# Altium schematic sheet photoreflective_face.SchDoc
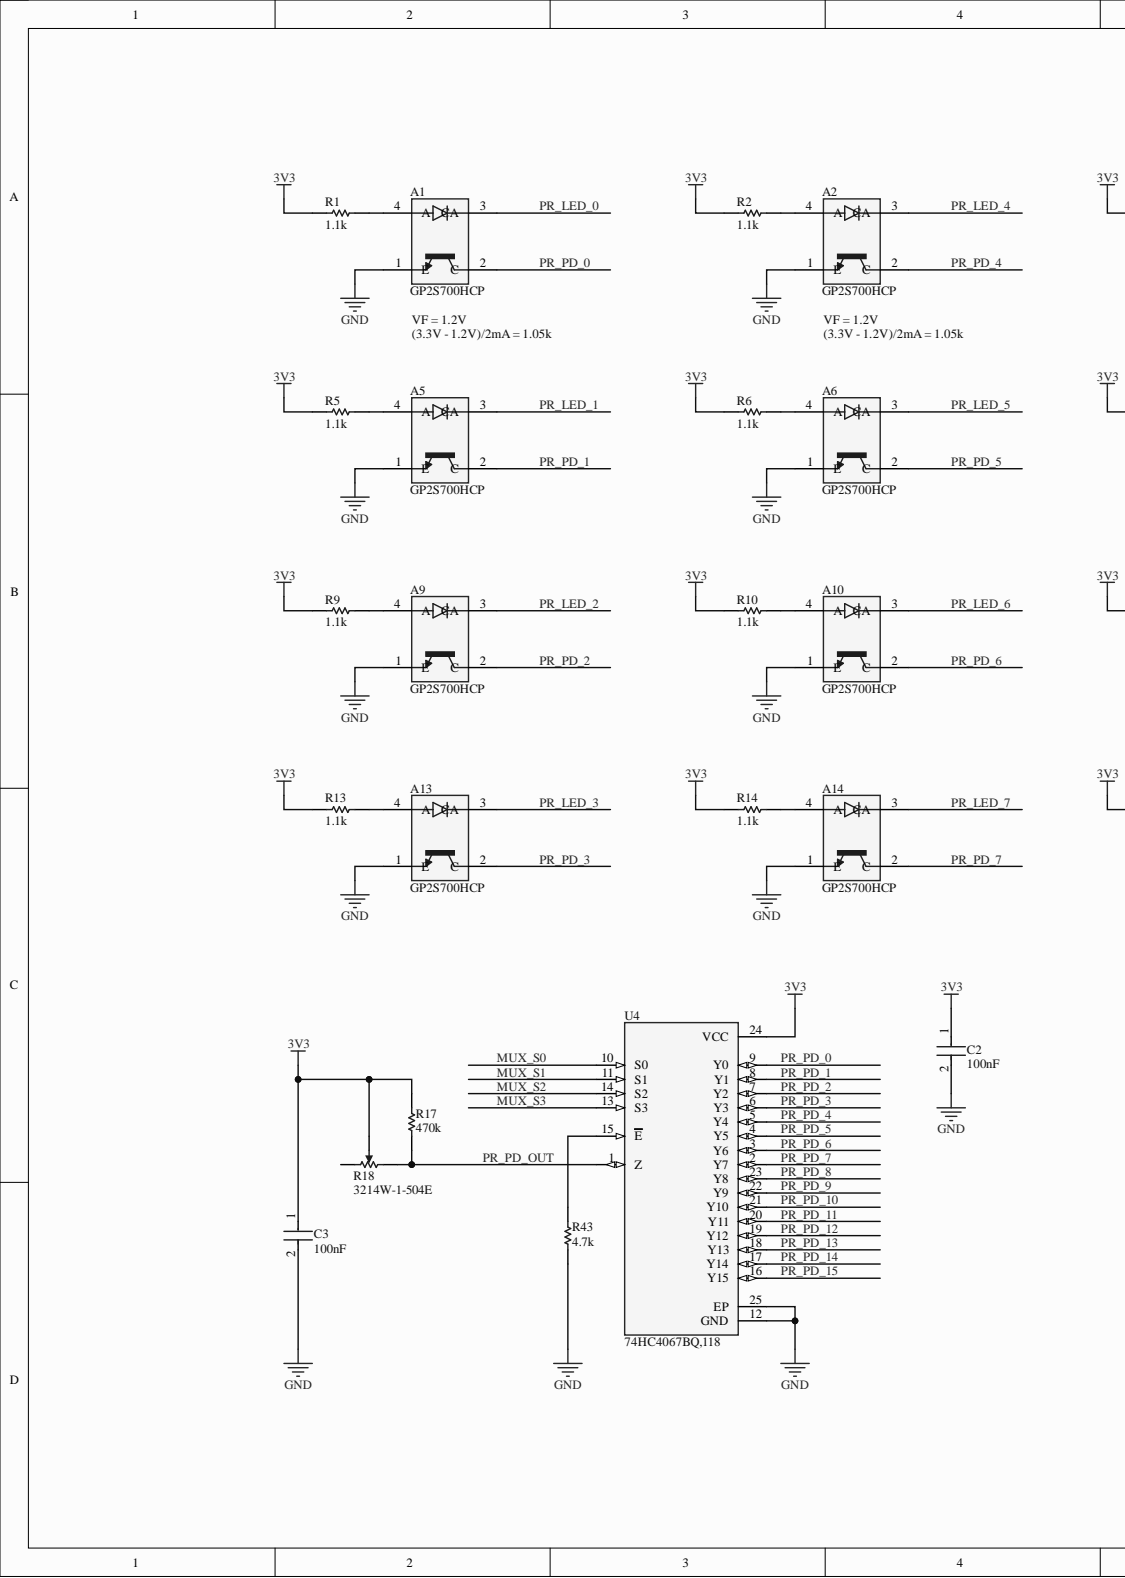
[diagram p0: photoreflective_face.SchDoc, left]
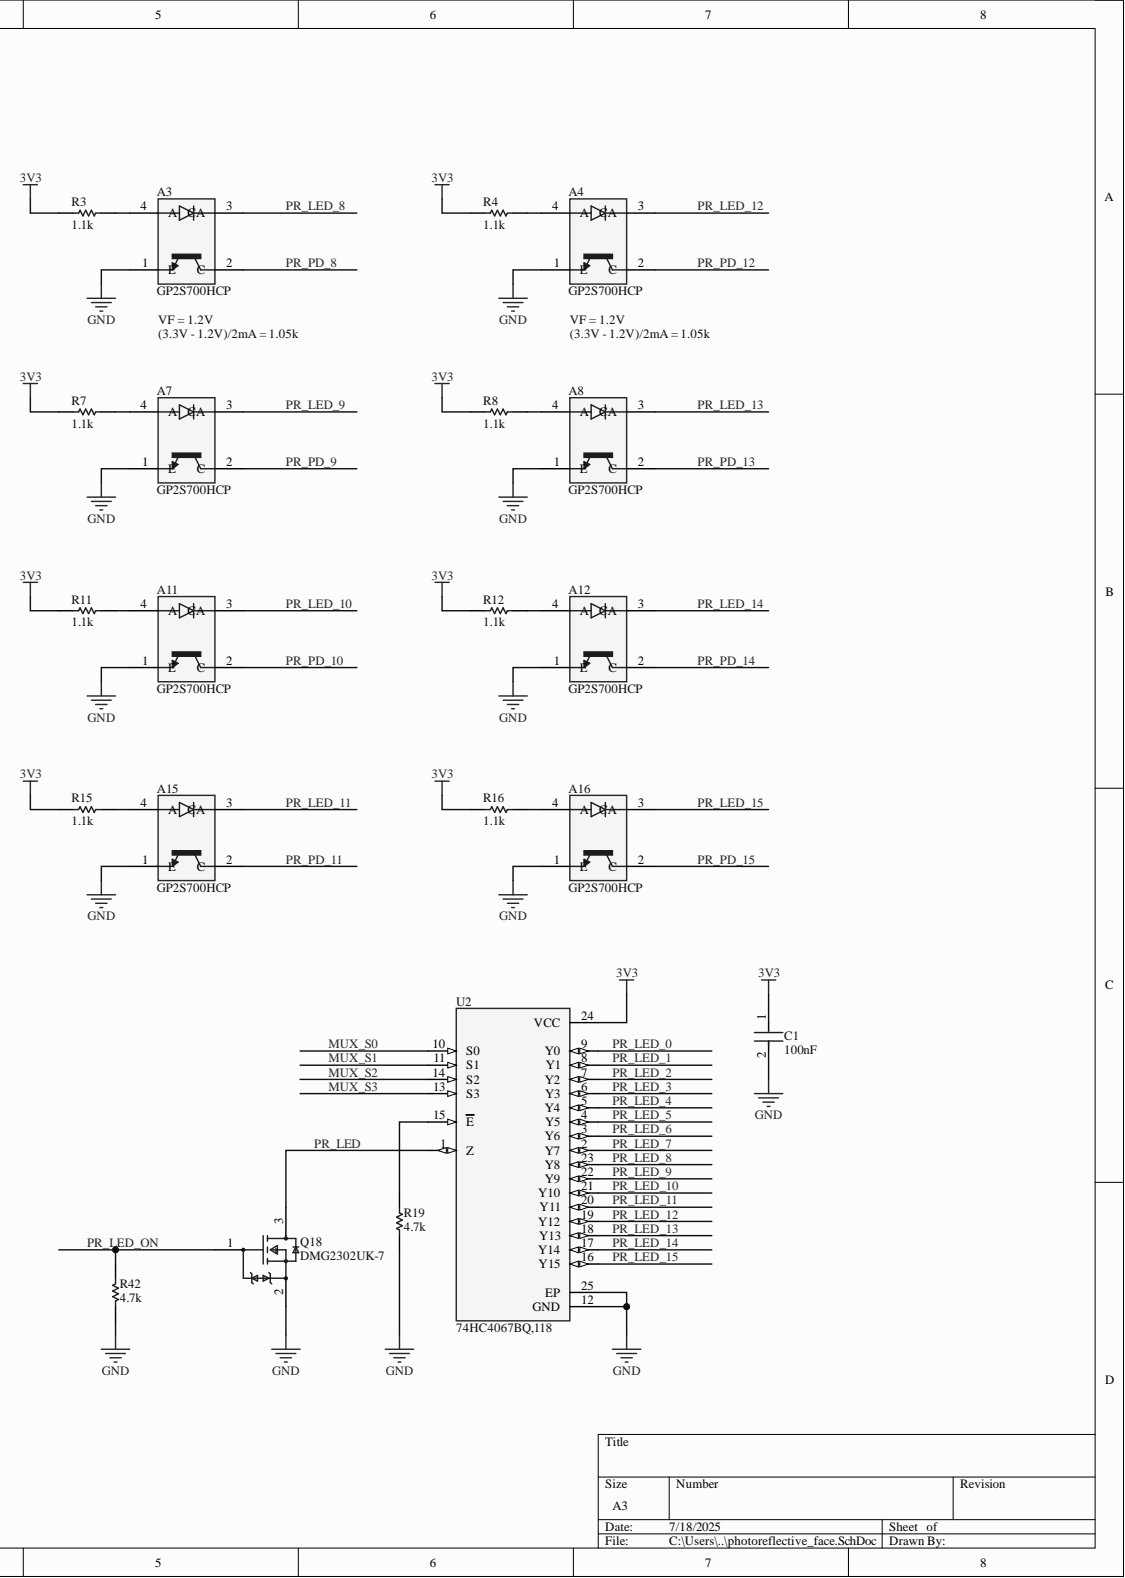
[diagram p1: photoreflective_face.SchDoc, right]

## Parts
A1  GP2S700HCP  [XDCR_GP2S700HCP]
A2  GP2S700HCP  [XDCR_GP2S700HCP]
A3  GP2S700HCP  [XDCR_GP2S700HCP]
A4  GP2S700HCP  [XDCR_GP2S700HCP]
A5  GP2S700HCP  [XDCR_GP2S700HCP]
A6  GP2S700HCP  [XDCR_GP2S700HCP]
A7  GP2S700HCP  [XDCR_GP2S700HCP]
A8  GP2S700HCP  [XDCR_GP2S700HCP]
A9  GP2S700HCP  [XDCR_GP2S700HCP]
A10  GP2S700HCP  [XDCR_GP2S700HCP]
A11  GP2S700HCP  [XDCR_GP2S700HCP]
A12  GP2S700HCP  [XDCR_GP2S700HCP]
A13  GP2S700HCP  [XDCR_GP2S700HCP]
A14  GP2S700HCP  [XDCR_GP2S700HCP]
A15  GP2S700HCP  [XDCR_GP2S700HCP]
A16  GP2S700HCP  [XDCR_GP2S700HCP]
C1  Capacitance: 100nF  [FP-GRM15-MFG]  (hidden comment: GRM155R71C104KA88D)
C2  Capacitance: 100nF  [FP-GRM15-MFG]  (hidden comment: GRM155R71C104KA88D)
C3  Capacitance: 100nF  [FP-GRM15-MFG]  (hidden comment: GRM155R71C104KA88D)
Q18  DMG2302UK-7  [FP-SOT23-MFG]
R1  value 1.1k  [RESC1005X40X25LL05T05]  (hidden comment: ERJ-2GEJ112X)
R2  value 1.1k  [RESC1005X40X25LL05T05]  (hidden comment: ERJ-2GEJ112X)
R3  value 1.1k  [RESC1005X40X25LL05T05]  (hidden comment: ERJ-2GEJ112X)
R4  value 1.1k  [RESC1005X40X25LL05T05]  (hidden comment: ERJ-2GEJ112X)
R5  value 1.1k  [RESC1005X40X25LL05T05]  (hidden comment: ERJ-2GEJ112X)
R6  value 1.1k  [RESC1005X40X25LL05T05]  (hidden comment: ERJ-2GEJ112X)
R7  value 1.1k  [RESC1005X40X25LL05T05]  (hidden comment: ERJ-2GEJ112X)
R8  value 1.1k  [RESC1005X40X25LL05T05]  (hidden comment: ERJ-2GEJ112X)
R9  value 1.1k  [RESC1005X40X25LL05T05]  (hidden comment: ERJ-2GEJ112X)
R10  value 1.1k  [RESC1005X40X25LL05T05]  (hidden comment: ERJ-2GEJ112X)
R11  value 1.1k  [RESC1005X40X25LL05T05]  (hidden comment: ERJ-2GEJ112X)
R12  value 1.1k  [RESC1005X40X25LL05T05]  (hidden comment: ERJ-2GEJ112X)
R13  value 1.1k  [RESC1005X40X25LL05T05]  (hidden comment: ERJ-2GEJ112X)
R14  value 1.1k  [RESC1005X40X25LL05T05]  (hidden comment: ERJ-2GEJ112X)
R15  value 1.1k  [RESC1005X40X25LL05T05]  (hidden comment: ERJ-2GEJ112X)
R16  value 1.1k  [RESC1005X40X25LL05T05]  (hidden comment: ERJ-2GEJ112X)
R17  value 470k  [RESC1005X40X25ML05T05]  (hidden comment: CRCW0402470KFKED)
R18  3214W-1-504E  [BOUR-3214W_V]
R19  value 4.7k  [RESC1005X40X25LL05T10]  (hidden comment: CRCW04024K70FKEDHP)
R42  value 4.7k  [RESC1005X40X25LL05T10]  (hidden comment: CRCW04024K70FKEDHP)
R43  value 4.7k  [RESC1005X40X25LL05T10]  (hidden comment: CRCW04024K70FKEDHP)
U2  74HC4067BQ,118  [QFN50P550X350X100-25N410X210]
U4  74HC4067BQ,118  [QFN50P550X350X100-25N410X210]

## Nets
3V3: C1.1 C2.1 C3.1 R1.2 R2.2 R3.2 R4.2 R5.2 R6.2 R7.2 R8.2 R9.2 R10.2 R11.2 R12.2 R13.2 R14.2 R15.2 R16.2 R17.1 R18.2 U2.24(VCC) U4.24(VCC)
GND: A1.1(E) A2.1(E) A3.1(E) A4.1(E) A5.1(E) A6.1(E) A7.1(E) A8.1(E) A9.1(E) A10.1(E) A11.1(E) A12.1(E) A13.1(E) A14.1(E) A15.1(E) A16.1(E) C1.2 C2.2 C3.2 Q18.2 R19.1 R42.1 R43.1 U2.12(GND) U2.25(EP) U4.12(GND) U4.25(EP)
MUX_S0: U2.10(S0) U4.10(S0)
MUX_S1: U2.11(S1) U4.11(S1)
MUX_S2: U2.14(S2) U4.14(S2)
MUX_S3: U2.13(S3) U4.13(S3)
PR_LED: Q18.3 U2.1(Z)
PR_LED_0: A1.3(CA) U2.9(Y0)
PR_LED_1: A5.3(CA) U2.8(Y1)
PR_LED_2: A9.3(CA) U2.7(Y2)
PR_LED_3: A13.3(CA) U2.6(Y3)
PR_LED_4: A2.3(CA) U2.5(Y4)
PR_LED_5: A6.3(CA) U2.4(Y5)
PR_LED_6: A10.3(CA) U2.3(Y6)
PR_LED_7: A14.3(CA) U2.2(Y7)
PR_LED_8: A3.3(CA) U2.23(Y8)
PR_LED_9: A7.3(CA) U2.22(Y9)
PR_LED_10: A11.3(CA) U2.21(Y10)
PR_LED_11: A15.3(CA) U2.20(Y11)
PR_LED_12: A4.3(CA) U2.19(Y12)
PR_LED_13: A8.3(CA) U2.18(Y13)
PR_LED_14: A12.3(CA) U2.17(Y14)
PR_LED_15: A16.3(CA) U2.16(Y15)
PR_LED_ON: Q18.1 R42.2
PR_PD_0: A1.2(C) U4.9(Y0)
PR_PD_1: A5.2(C) U4.8(Y1)
PR_PD_2: A9.2(C) U4.7(Y2)
PR_PD_3: A13.2(C) U4.6(Y3)
PR_PD_4: A2.2(C) U4.5(Y4)
PR_PD_5: A6.2(C) U4.4(Y5)
PR_PD_6: A10.2(C) U4.3(Y6)
PR_PD_7: A14.2(C) U4.2(Y7)
PR_PD_8: A3.2(C) U4.23(Y8)
PR_PD_9: A7.2(C) U4.22(Y9)
PR_PD_10: A11.2(C) U4.21(Y10)
PR_PD_11: A15.2(C) U4.20(Y11)
PR_PD_12: A4.2(C) U4.19(Y12)
PR_PD_13: A8.2(C) U4.18(Y13)
PR_PD_14: A12.2(C) U4.17(Y14)
PR_PD_15: A16.2(C) U4.16(Y15)
PR_PD_OUT: R17.2 R18.1 U4.1(Z)
NetA1_4: A1.4(A) R1.1
NetA2_4: A2.4(A) R2.1
NetA3_4: A3.4(A) R3.1
NetA4_4: A4.4(A) R4.1
NetA5_4: A5.4(A) R5.1
NetA6_4: A6.4(A) R6.1
NetA7_4: A7.4(A) R7.1
NetA8_4: A8.4(A) R8.1
NetA9_4: A9.4(A) R9.1
NetA10_4: A10.4(A) R10.1
NetA11_4: A11.4(A) R11.1
NetA12_4: A12.4(A) R12.1
NetA13_4: A13.4(A) R13.1
NetA14_4: A14.4(A) R14.1
NetA15_4: A15.4(A) R15.1
NetA16_4: A16.4(A) R16.1
NetR19_2: R19.2 U2.15(E)
NetR43_2: R43.2 U4.15(E)

Not connected: R18 3

## Library data of the parts
A1-A16 = GP2S700HCP: Photointerrupter Transmissive Phototransistor 4-Pin SMT; MF: Sharp Electronics; Availability: In Stock; Check_prices: https://www.snapeda.com/parts/GP2S700HCP/Saha%20Electronics/view-part/5519816/?ref=eda; Component Kind: Standard; Library Name: GP2S700HCP.IntLib; MP: GP2S700HCP; Package: None; Price: None; Purchase-URL: https://pricing.snapeda.com/search/part/GP2S700HCP/?ref=eda; SnapEDA_Link: https://www.snapeda.com/parts/GP2S700HCP/Saha%20Electronics/view-part/5519816/?ref=snap
C1-C3 = CMP-2100-03641-2: CAP CER 0.1UF 16V X7R 0402; Manufacturer: Murata; Material: Ceramic; Standoff Height: 0mm; Tolerance: 10%; Value: 0.10μF; Voltage Rating: 16Vdc; Category: Cap; Component Kind: Standard; ComponentLink2Description: Datasheet; ComponentLink2URL: https://search.murata.co.jp/Ceramy/image/img/A01X/G101/ENG/GRM155R71H471KA01-01A.pdf; Device Class L1: Passive Components; Device Class L2: Capacitors; Device Class L3: Ceramic Capacitors; Digikey Description: CAP CER 0.1UF 16V X7R 0402; Height: 0.55mm; Ipc Land Pattern Name: CAPC10050X219; Lead Free: yes; Library Name: Altium Content Vault; Manufacturer Part Number: GRM155R71C104KA88D; Mouser Description: 0402 0.1 uF 16V ±10 % Tolerance X7R SMT Multilayer Ceramic Capacitor; Octopart: https://octopart.com/grm155r71c104ka88d-murata-196880; Package: GRM15; RoHS: TRUE; Temperature: -55°C to +125°C; Temperature Characteristic: X7R; Temperature Range High: +125°C; Temperature Range Low: -55°C
Q18 = CMP-12801-000001-1: MOSFET N-CH 20V 2.8A SOT23; Manufacturer: Diodes Inc.; Automotive Grade: Grade 0; Depletion Mode: False; Drain To Source Resistance: 61mΩ; Gate Charge At Vgs: 2.8nC @ 10V; Gate To Source Voltage: 12V; Input Capacitace At Vds: 130pF @ 10V; Max Forward Diode Voltage: 1.2V; Max Junction Temp: +150°C; Number Of Channels: 1; Power Dissipation: 0.66W; Pulse Drain Current: 12A; Reverse Recovery Charge: 0.5nC; Reverse Recovery Time: 5.3ns; Rthja Max: 192°C/W; Standoff Height: 0.013mm; Threshold Vgs Max: 1V; Threshold Vgs Min: 0.3V; Turn Off Delay Time: 4.2ns; Turn On Delay Time: 0.6ns; Typ Forward Diode Voltage: 0.7V; Automotive: Yes; Category: Trans; Component Kind: Standard; ComponentLink2Description: Datasheet; ComponentLink2URL: https://www.diodes.com/assets/Datasheets/DMG2302UK.pdf; Continuous Drain Current: 2.8A; Device Class L1: Discrete Semiconductors; Device Class L2: Transistors; Device Class L3: MOSFETs; Digikey Description: MOSFET N-CH 20V 2.8A SOT23; Drain To Source Breakdown Voltage: 20V; Drain To Source Voltage: 20V; Footprint Url: https://www.diodes.com/assets/Package-Files/SOT23.pdf; Height: 1.1mm; Lead Free: Yes; Library Name: Altium Content Vault; Manufacturer Part Number: DMG2302UK-7; Number Of N Channels: 1; Octopart: https://octopart.com/dmg2302uk-7-diodes+inc.-73850182; Package: SOT23; RoHS: TRUE; Temperature: -55°C to +150°C; Temperature Range High: +150°C; Temperature Range Low: -55°C
R1-R16 = CMP-2002-00439-1: Manufacturer: Panasonic; Component Kind: Standard; Library Name: Altium Content Vault; Part Number: ERJ-2GEJ112X
R17 = CMP-2002-00020-1: Manufacturer: Vishay Dale; Component Kind: Standard; Library Name: Altium Content Vault; Part Number: CRCW0402470KFKED
R18 = CMP-2000-05579-1: 3214 - 5-Turn Trimpot(R) Trimming Potentiometer, 5 KOhm, +/- 10%, 0.25 W, -65 to 150 degC, RoHS, Tape and Reel; Manufacturer: Bourns; No. of Turns: 5; Potentiometer Mounting: SMD; Power Rating: 250mW; SVHC: NoSVHC(16-Jun-2014); Temperature Coefficient: ±100ppm/°C; Track Resistance: 5kohm; Component Kind: Standard; Datasheet URL: http://www.bourns.com/docs/Product-Datasheets/3214.pdf; Datasheet Version: 05/2016; Library Name: Altium Content Vault; Manufacturer URL: http://www.bourns.com/; Mounting Technology: SurfaceMount; Package Description: 3-Pin Surface Mount Device, Body 3.5 x 4.8 mm, Pitch 1.25 mm; Package Reference: 3214W; Resistance Tolerance: ±10%; ROHS COMPLIANT: Yes
R19 R42 R43 = CMP-2002-03050-1: Manufacturer: Vishay Dale; Component Kind: Standard; Library Name: Altium Content Vault; Part Number: CRCW04024K70FKEDHP
U2 U4 = 74HC4067BQ,118: 1 Circuit IC Switch 16:1 120Ohm 24-DHVQFN (5.5x3.5); MF: Nexperia USA Inc.; Check_prices: https://www.snapeda.com/parts/74HC4067BQ,118/Nexperia/view-part/?ref=eda; Component Kind: Standard; Library Name: 74HC4067BQ_118.IntLib; MP: 74HC4067BQ,118; Package: DHVQFN24-24 Nexperia; SnapEDA_Link: https://www.snapeda.com/parts/74HC4067BQ,118/Nexperia/view-part/?ref=snap
